# Altium schematic sheet buttons_controller.SchDoc
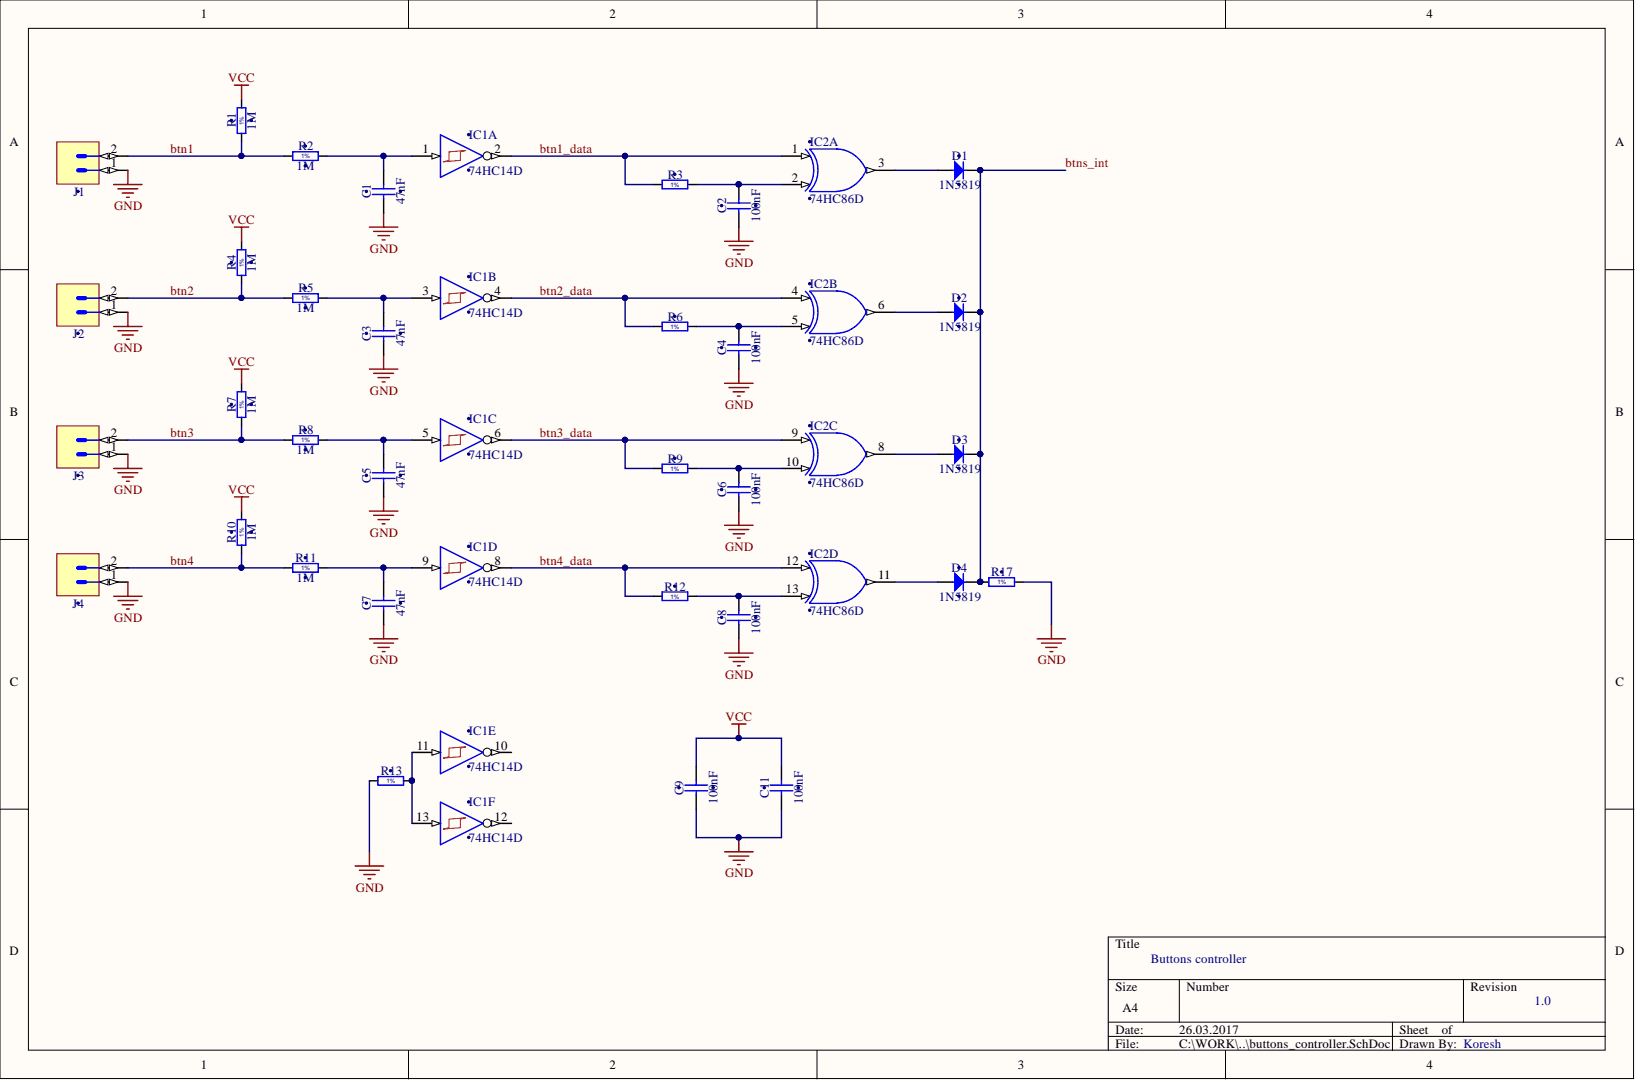
[diagram p0: buttons_controller.SchDoc]

## Parts
C1  47nF  [CAPC1608X90N]
C2  100nF  [CAPC1608X90N]
C3  47nF  [CAPC1608X90N]
C4  100nF  [CAPC1608X90N]
C5  47nF  [CAPC1608X90N]
C6  100nF  [CAPC1608X90N]
C7  47nF  [CAPC1608X90N]
C8  100nF  [CAPC1608X90N]
C9  100nF  [CAPC1608X90N]
C11  100nF  [CAPC1608X90N]
D1  1N5819  [SOD2713X115N]
D2  1N5819  [SOD2713X115N]
D3  1N5819  [SOD2713X115N]
D4  1N5819  [SOD2713X115N]
IC1  74HC14D  [SOIC127P600X175-14N]  (parts A,B,C,D,E,F)
IC2  74HC86D  [SOIC127P600X175-14N]  (parts A,B,C,D)
J1  d4  [MOLEX_53047-0210]  (value not drawn)
J2  d5  [MOLEX_53047-0210]  (value not drawn)
J3  d6  [MOLEX_53047-0210]  (value not drawn)
J4  d7  [MOLEX_53047-0210]  (value not drawn)
R1  1M  [RESC1608X55N]
R2  1M  [RESC1608X55N]
R3  10k  [RESC1608X55N]  (value not drawn)
R4  1M  [RESC1608X55N]
R5  1M  [RESC1608X55N]
R6  10k  [RESC1608X55N]  (value not drawn)
R7  1M  [RESC1608X55N]
R8  1M  [RESC1608X55N]
R9  10k  [RESC1608X55N]  (value not drawn)
R10  1M  [RESC1608X55N]
R11  1M  [RESC1608X55N]
R12  10k  [RESC1608X55N]  (value not drawn)
R13  10k  [RESC1608X55N]  (value not drawn)
R17  10k  [RESC1608X55N]  (value not drawn)

## Nets
GND: C1.1 C2.1 C3.1 C4.1 C5.1 C6.1 C7.1 C8.1 C9.1 C11.1 IC1.7 IC2.7 J1.1 J2.1 J3.1 J4.1 R13.1 R17.2
VCC: C9.2 C11.2 IC1.14 IC2.14 R1.2 R4.2 R7.2 R10.2
BTN1: J1.2 R1.1 R2.1
BTN1_DATA: IC1.2 IC2.1 R3.1
BTN2: J2.2 R4.1 R5.1
BTN2_DATA: IC1.4 IC2.4 R6.1
BTN3: J3.2 R7.1 R8.1
BTN3_DATA: IC1.6 IC2.9 R9.1
BTN4: J4.2 R10.1 R11.1
BTN4_DATA: IC1.8 IC2.12 R12.1
BTNS_INT: D1.1 D2.1 D3.1 D4.1 R17.1
NetC1_2: C1.2 IC1.1 R2.2
NetC2_2: C2.2 IC2.2 R3.2
NetC3_2: C3.2 IC1.3 R5.2
NetC4_2: C4.2 IC2.5 R6.2
NetC5_2: C5.2 IC1.5 R8.2
NetC6_2: C6.2 IC2.10 R9.2
NetC7_2: C7.2 IC1.9 R11.2
NetC8_2: C8.2 IC2.13 R12.2
NetD1_2: D1.2 IC2.3
NetD2_2: D2.2 IC2.6
NetD3_2: D3.2 IC2.8
NetD4_2: D4.2 IC2.11
NetIC1_11: IC1.11 IC1.13 R13.2

Not connected: IC1 10 12

## Library data of the parts
C1 C3 C5 C7 = CC0603_1NF_50V_5%_NP0: Non-polarised capacitor; Manufacturer: GENERIC; Manufacturer1 Part Number: CC0603JRNPO9BN102; Part Description: SMD Multilayer Chip Ceramic Capacitor; Database Table Name: Capacitors SMD; TC: NP0; Tolerance: ±5%; Voltage: 50V; Case: 0603; Component Kind: Standard; Component Type: Standard; CreateDate: 22.10.2012; HelpURL: \\cern.ch\dfs\Applications\Altium\Datasheets\CC0805_NP0_PHYCOMP_16VTO50V.pdf; LatestRevisionDate: 22.10.2012; Library Name: Capacitors.DbLib; Manufacturer1 ComponentHeight: 0.9mm; Manufacturer1 Example: YAGEO PHYCOMP; Manufacturer Part Number: CC0603_1NF_50V_5%_NP0; Mounted: Yes; Part Number: CC0603_1NF_50V_5%_NP0; Pin Count: 2; SMD: Yes; Socket: No; Status: Preferred; Table: Capacitors SMD; Value: 47nF; Author: CERN DEM JLC
C2 C4 C6 C8 C9 C11 = CC0603_100NF_100V_10%_X7R: Non-polarised capacitor; Manufacturer: GENERIC; Manufacturer1 Part Number: GRM188R72A104KA35D; Part Description: SMD Multilayer Chip Ceramic Capacitor; Database Table Name: Capacitors SMD; TC: X7R; Tolerance: ±10%; Voltage: 100V; Case: 0603; Component Kind: Standard; Component Type: Standard; CreateDate: 11.12.2013; HelpURL: \\cern.ch\dfs\Applications\Altium\Datasheets\CC0603_MURATA_GRM188R72A104KA35D.pdf; LatestRevisionDate: 11.12.2013; Library Name: Capacitors.DbLib; Manufacturer1 ComponentHeight: 0.9mm; Manufacturer1 Example: MURATA; Manufacturer Part Number: CC0603_100NF_100V_10%_X7R; Mounted: Yes; Part Number: CC0603_100NF_100V_10%_X7R; Pin Count: 2; SMD: Yes; Socket: No; Status: None; Table: Capacitors SMD; Value: 100nF; Author: CERN DEM JLC
D1-D4 = 1N4148WS-E3-08: Diode; Manufacturer: VISHAY SEMICONDUCTORS; Part Description: Small Signal Fast Switching Diode; Database Table Name: Diodes; Power: 150mA; Voltage: 75V; Case: SOD-323; ComponentHeight: 1.15mm; Component Kind: Standard; Component Type: Standard; CreateDate: 16.12.2013; Device: 1N5819; HelpURL: \\cern.ch\dfs\Applications\Altium\Datasheets\1N4148WS-E3-08.pdf; LatestRevisionDate: 16.12.2013; Library Name: ICs And Semiconductors.DbLib; Manufacturer Part Number: 1N4148WS-E3-08; Mounted: Yes; PackageDescription: SOD-323 Diode; 1.775mm W X 1.30mm L X 1.15mm H Body, IPC Medium Density; Part Number: 1N4148WS-E3-08; Pin Count: 3; SMD: Yes; Socket: No; Table: Diodes; Author: CERN DEM JLC
IC1 = SN74HCT14D: Hex Schmitt-Trigger Inverter; Manufacturer: TEXAS INSTRUMENTS; Database Table Name: Standard Logic; Case: SOIC14; ComponentHeight: 1.75mm; Component Kind: Standard; Component Type: Standard; CreateDate: 06.07.2009; Device: 74HC14D; HelpURL: \\cern.ch\dfs\Applications\Altium\Datasheets\SN74HCT14D.pdf; LatestRevisionDate: 06.07.2009; Library Name: ICs And Semiconductors.DbLib; Manufacturer Part Number: SN74HCT14D; Mounted: Yes; PackageDescription: SOIC 14, Pitch 1.27mm - Body 4x8.75mm, IPC Medium Density; Part Description: Hex Schmitt-Trigger Inverter; Part Number: SN74HCT14D; Pin Count: 14; SMD: Yes; Socket: No; Table: Standard Logic; Author: CERN DEM JLC
IC2 = SN74HC86D: Quadruple 2-Input Exclusive-OR Gates; Manufacturer: TEXAS INSTRUMENTS; Database Table Name: Standard Logic; Case: SOIC14; ComponentHeight: 1.75mm; Component Kind: Standard; Component Type: Standard; CreateDate: 31.07.2012; Device: 74HC86D; HelpURL: \\cern.ch\dfs\Applications\Altium\Datasheets\SN74HC86D.pdf; LatestRevisionDate: 31.07.2012; Library Name: ICs And Semiconductors.DbLib; Manufacturer Part Number: SN74HC86D; Mounted: Yes; PackageDescription: SOIC 14, Pitch 1.27mm - Body 4x8.75mm, IPC Medium Density; Part Description: Quadruple 2-Input Exclusive-OR Gates; Part Number: SN74HC86D; Pin Count: 14; SMD: Yes; Socket: No; Table: Standard Logic; Author: CERN DEM JLC
J1 = MOLEX_53047-0210: Connector 2 Male, micro JST 1.25mm; Part Description: 1.25mm Pitch PicoBlade™ Wire-to-Board 2 Circuits Vertical Header with Friction Lock; Database Table Name: MOLEX; ComponentHeight: 4.2mm; Component Kind: Standard; Component Type: Standard; CreateDate: 20.03.2013; Family: PicoBlade; HelpURL: \\cern.ch\dfs\Applications\Altium\Datasheets\MOLEX_53047-0210.pdf; LatestRevisionDate: 20.03.2013; Library Name: Connectors.DbLib; Manufacturer: MOLEX; Manufacturer Part Number: 53047-0210; Mounted: Yes; Part Number: MOLEX_53047-0210; Pin Count: 2; SMD: No; Socket: No; Status: None; Table: MOLEX; Author: CERN DEM JLC
J2-J4 = MOLEX_53047-0210: Connector 2 Male; Part Description: 1.25mm Pitch PicoBlade™ Wire-to-Board 2 Circuits Vertical Header with Friction Lock; Database Table Name: MOLEX; ComponentHeight: 4.2mm; Component Kind: Standard; Component Type: Standard; CreateDate: 20.03.2013; Family: PicoBlade; HelpURL: \\cern.ch\dfs\Applications\Altium\Datasheets\MOLEX_53047-0210.pdf; LatestRevisionDate: 20.03.2013; Library Name: Connectors.DbLib; Manufacturer: MOLEX; Manufacturer Part Number: 53047-0210; Mounted: Yes; Part Number: MOLEX_53047-0210; Pin Count: 2; SMD: No; Socket: No; Status: None; Table: MOLEX; Author: CERN DEM JLC
R1 R4 R7 R10 = R0603_1M_1%_0.1W_100PPM: Resistor - 1%; Manufacturer: GENERIC; Manufacturer1 Part Number: NRC06F1004TRF; Part Description: General Purpose Thick Film Chip Resistor; Part Number: R0603_10M_1%_0.1W_100PPM; Database Table Name: Resistors SMD; Power: 0.1W; TC: ±100ppm/°C; Case: 0603; Component Kind: Standard; Component Type: Standard; CreateDate: 03.12.2007; HelpURL: \\cern.ch\dfs\Applications\Altium\Datasheets\R0603_NIC_NRC.pdf; LatestRevisionDate: 13.03.2008; Library Name: Resistors.DbLib; Manufacturer1 ComponentHeight: 0.55mm; Manufacturer1 Example: NIC COMPONENT; Manufacturer Part Number: R0603_1M_1%_0.1W_100PPM; Mounted: Yes; Pin Count: 2; SMD: Yes; Socket: No; Status: Preferred; Table: Resistors SMD; Tolerance: ±1%; Value: 1M; Author: CERN DEM JLC
R2 R5 R8 R11 = R0603_1M_1%_0.1W_100PPM: Resistor - 1%; Manufacturer: GENERIC; Manufacturer1 Part Number: NRC06F1004TRF; Part Description: General Purpose Thick Film Chip Resistor; Database Table Name: Resistors SMD; Power: 0.1W; TC: ±100ppm/°C; Case: 0603; Component Kind: Standard; Component Type: Standard; CreateDate: 03.12.2007; HelpURL: \\cern.ch\dfs\Applications\Altium\Datasheets\R0603_NIC_NRC.pdf; LatestRevisionDate: 13.03.2008; Library Name: Resistors.DbLib; Manufacturer1 ComponentHeight: 0.55mm; Manufacturer1 Example: NIC COMPONENT; Manufacturer Part Number: R0603_1M_1%_0.1W_100PPM; Mounted: Yes; Part Number: R0603_1M_1%_0.1W_100PPM; Pin Count: 2; SMD: Yes; Socket: No; Status: Preferred; Table: Resistors SMD; Tolerance: ±1%; Value: 1M; Author: CERN DEM JLC
R3 R6 R9 R12 R13 R17 = R0603_10K_1%_0.1W_100PPM: Resistor - 1%; Manufacturer: GENERIC; Manufacturer1 Part Number: NRC06F1002TRF; Part Description: General Purpose Thick Film Chip Resistor; Database Table Name: Resistors SMD; Power: 0.1W; TC: ±100ppm/°C; Case: 0603; Component Kind: Standard; Component Type: Standard; CreateDate: 03.12.2007; HelpURL: \\cern.ch\dfs\Applications\Altium\Datasheets\R0603_NIC_NRC.pdf; LatestRevisionDate: 13.03.2008; Library Name: Resistors.DbLib; Manufacturer1 ComponentHeight: 0.55mm; Manufacturer1 Example: NIC COMPONENT; Manufacturer Part Number: R0603_10K_1%_0.1W_100PPM; Mounted: Yes; Part Number: R0603_10K_1%_0.1W_100PPM; Pin Count: 2; SMD: Yes; Socket: No; Status: Preferred; Table: Resistors SMD; Tolerance: ±1%; Value: 10k; Author: CERN DEM JLC
